# Altium schematic sheet Potenciostato2.SchDoc(Potenciostato2)
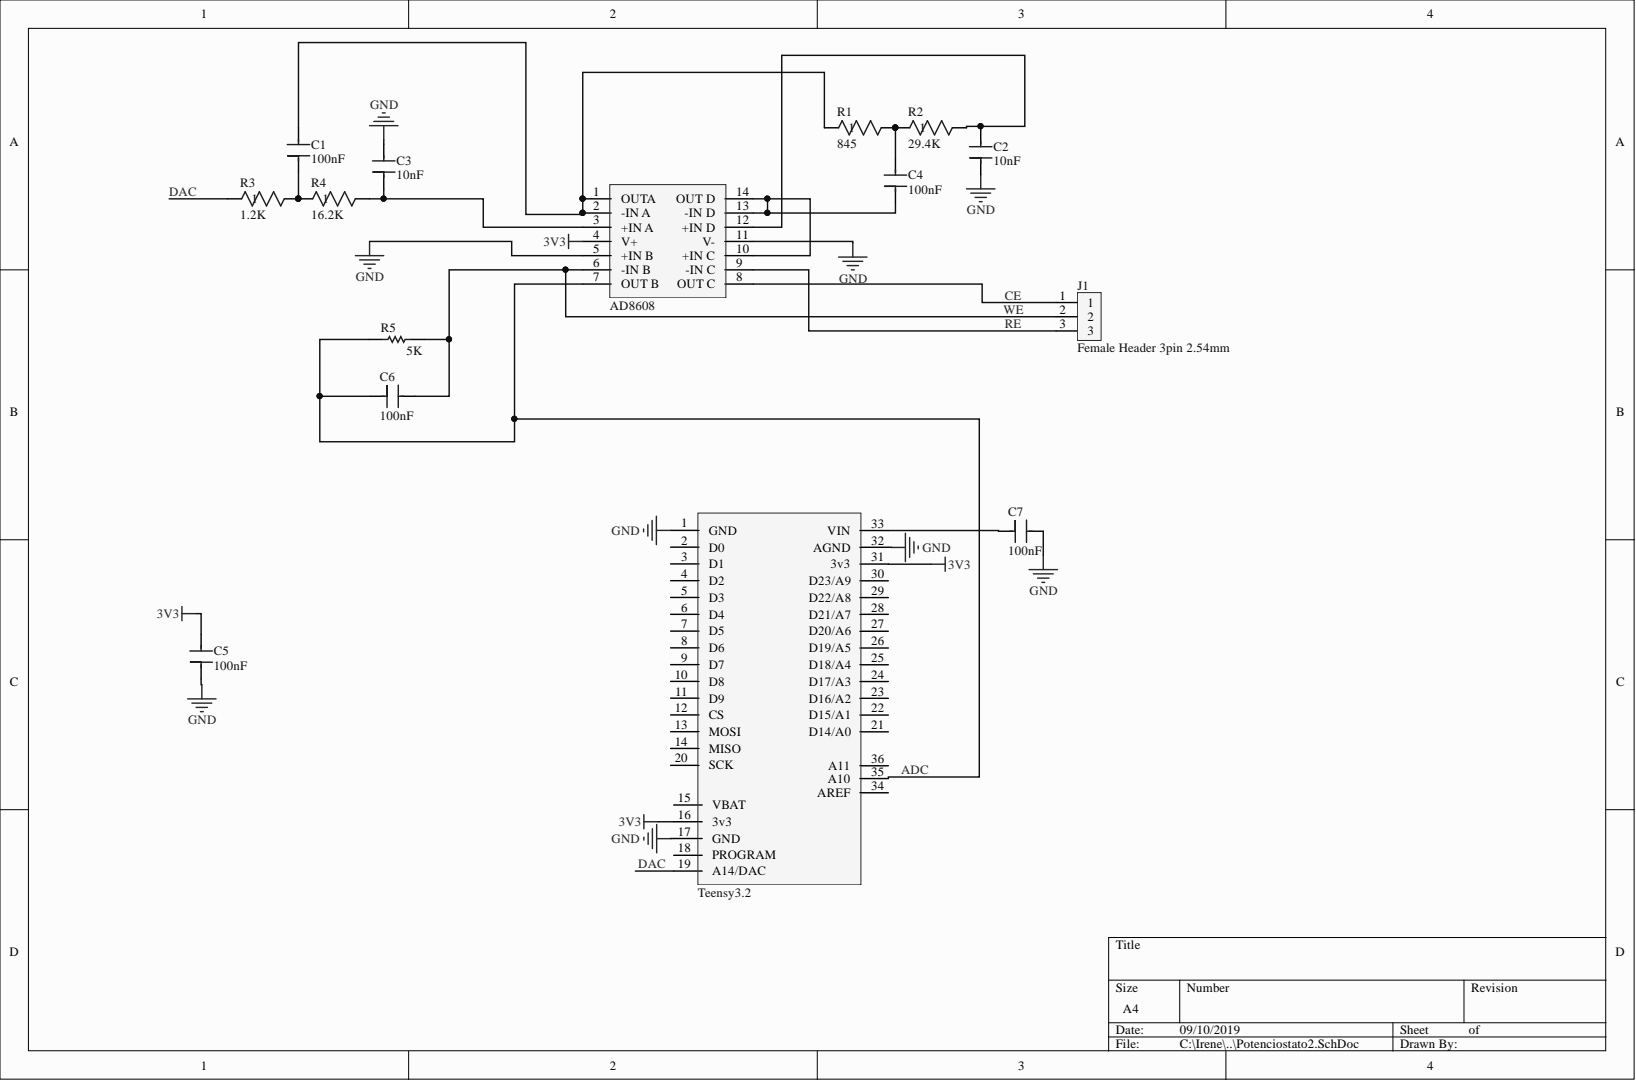
[diagram p0: Potenciostato2.SchDoc]

## Parts
AD8608  AD8608  [AD8608]
C1  100nF  [C100nF]
C2  10nF  [C100nF]
C3  10nF  [C100nF]
C4  100nF  [C100nF]
C5  100nF  [C100nF]
C6  100nF  [C100nF]
C7  100nF  [C100nF]
J1  Female Header 3pin 2.54mm  [Female Header 2.54mm 3pin]
R1  845  [RT0603]
R2  29.4K  [RR0816]
R3  1.2K  [RR0510]
R4  16.2K  [RT0805]
R5  ERJ-2RKF1000X  [RES0402]  (value not drawn)
Teensy_3.2  Teensy3.2  [Teensy 3.2]

## Nets
3V3: AD8608.4(V+) C5.1 Teensy_3.2.16(3v3) Teensy_3.2.31(3v3)
GND: AD8608.5(+IN_B) AD8608.11(V-) C2.1 C3.2 C5.2 C7.2 Teensy_3.2.1(GND) Teensy_3.2.17(GND) Teensy_3.2.32(AGND)
ADC: AD8608.7(OUT_B) C6.1 R5.1 Teensy_3.2.35(A10)
CE: AD8608.8(OUT_C) J1.1
DAC: R3.1 Teensy_3.2.19(A14/DAC)
RE: AD8608.9(-IN_C) J1.3
WE: AD8608.6(-IN_B) C6.2 J1.2 R5.2
NetAD8608_1: AD8608.1(OUTA) AD8608.2(-IN_A) C1.2 R1.1
NetAD8608_3: AD8608.3(+IN_A) C3.1 R4.2
NetAD8608_10: AD8608.10(+IN_C) AD8608.13(-IN_D) AD8608.14(OUT_D) C4.1
NetAD8608_12: AD8608.12(+IN_D) C2.2 R2.2
NetC1_1: C1.1 R3.2 R4.1
NetC4_2: C4.2 R1.2 R2.1
NetC7_1: C7.1 Teensy_3.2.33(VIN)

Not connected: Teensy_3.2 2(D0) 3(D1) 4(D2) 5(D3) 6(D4) 7(D5) 8(D6) 9(D7) 10(D8) 11(D9) 12(CS) 13(MOSI) 14(MISO) 15(VBAT) 18(PROGRAM) 20(SCK) 21(D14/A0) 22(D15/A1) 23(D16/A2) 24(D17/A3) 25(D18/A4) 26(D19/A5) 27(D20/A6) 28(D21/A7) 29(D22/A8) 30(D23/A9) 34(AREF) 36(A11)

## Library data of the parts
C1 C4-C7 = C100nF: Supplier Part Number 1: 490-16364-1-ND; Supplier 1: Digi-Key; Component Kind: Standard; Library Name: Potenciostato.SchLib
C2 C3 = C10nF: Supplier Part Number 1: 490-16364-1-ND; Supplier 1: Digi-Key; Component Kind: Standard; Library Name: Potenciostato.SchLib
R1 = RT0603: Supplier Part Number 1: 311-845DCT-ND; Supplier 1: Digi-Key; Component Kind: Standard; Library Name: Potenciostato.SchLib
R2 = RR0816: Supplier Part Number 1: RR08P29.4KDCT-ND; Supplier 1: Digi-Key; Component Kind: Standard; Library Name: Potenciostato.SchLib
R3 = RR0510P-122-D: No Description Available; Vendor: Susumu; Built_By: EMA_UL_Team; Value: Value; Type: DEV; Supplier Part Number 1: RR05P1.2KDDKR-ND; Supplier 1: Digi-Key; RefDes: RefDes; Manufacturer_Part_Number: RR0510P-122-D; Component Kind: Standard; Library Name: Potenciostato.SchLib; Copyright: Copyright (C) 2018 Accelerated Designs. All rights reserved
R4 = RT0805: Supplier Part Number 1: YAG5976CT-ND; Supplier 1: Digi-Key; Component Kind: Standard; Library Name: Potenciostato.SchLib
R5 = RES0402: Chip Resistor, 100 Ohm, +/- 1%, 100 mW, -55 to 155 degC, 0402 (1005 Metric), RoHS, Tape and Reel; Manufacturer: Basic Passives; Voltage Rating: 50 V; Resistance: 100 Ohm; Power Rating: 100 mW; Case\/Package: 0402; Width: 0.5 mm; Tolerance: 1%; Supplier Part Number 1: 603-RC0402FR-075KL; Supplier 1: Mouser; RoHSCompliant: true; REACH SVHC: Yes; Radiation Hardening: No; Pins: 2; PartNumber: ERJ-2RKF1000X; Packaging: Tape and Reel; PackageReference: 0402; Package Quantity: 10000; PackageDescription: 2-Pin Surface Mount Device, Body 1 x 0.5 mm; Mounting Technology: Surface Mount; Mount: Surface Mount; Min Operating Temperature: -55 degC; Max Operating Temperature: 155 degC; Length: 1 mm; Height: 0.35 mm; DatasheetVersion: 04/2015; ComponentLink2URL: http://industrial.panasonic.com/cdbs/www-data/pdf/RDA0000/AOA0000C86.pdf; ComponentLink2Description: Datasheet; ComponentLink1URL: http://www.panasonic.com/; ComponentLink1Description: Manufacturer URL; Component Kind: Standard; Case Code (Metric): 1005; Case Code (Imperial): 0402; Library Name: Potenciostato.SchLib
Teensy_3.2 = Teensy3.1: Component Kind: Standard; Library Name: Potenciostato.SchLib
AD8608 = AD8608: Supplier Part Number 1: AD8608ARZ-ND; Supplier 1: Digi-Key; Component Kind: Standard; Library Name: Potenciostato.SchLib
J1 = Female Header 3pin 2.54mm: Component Kind: Standard; Library Name: Potenciostato.SchLib
